# Altium schematic sheet RS232-485.SchDoc(U_RS232-485)
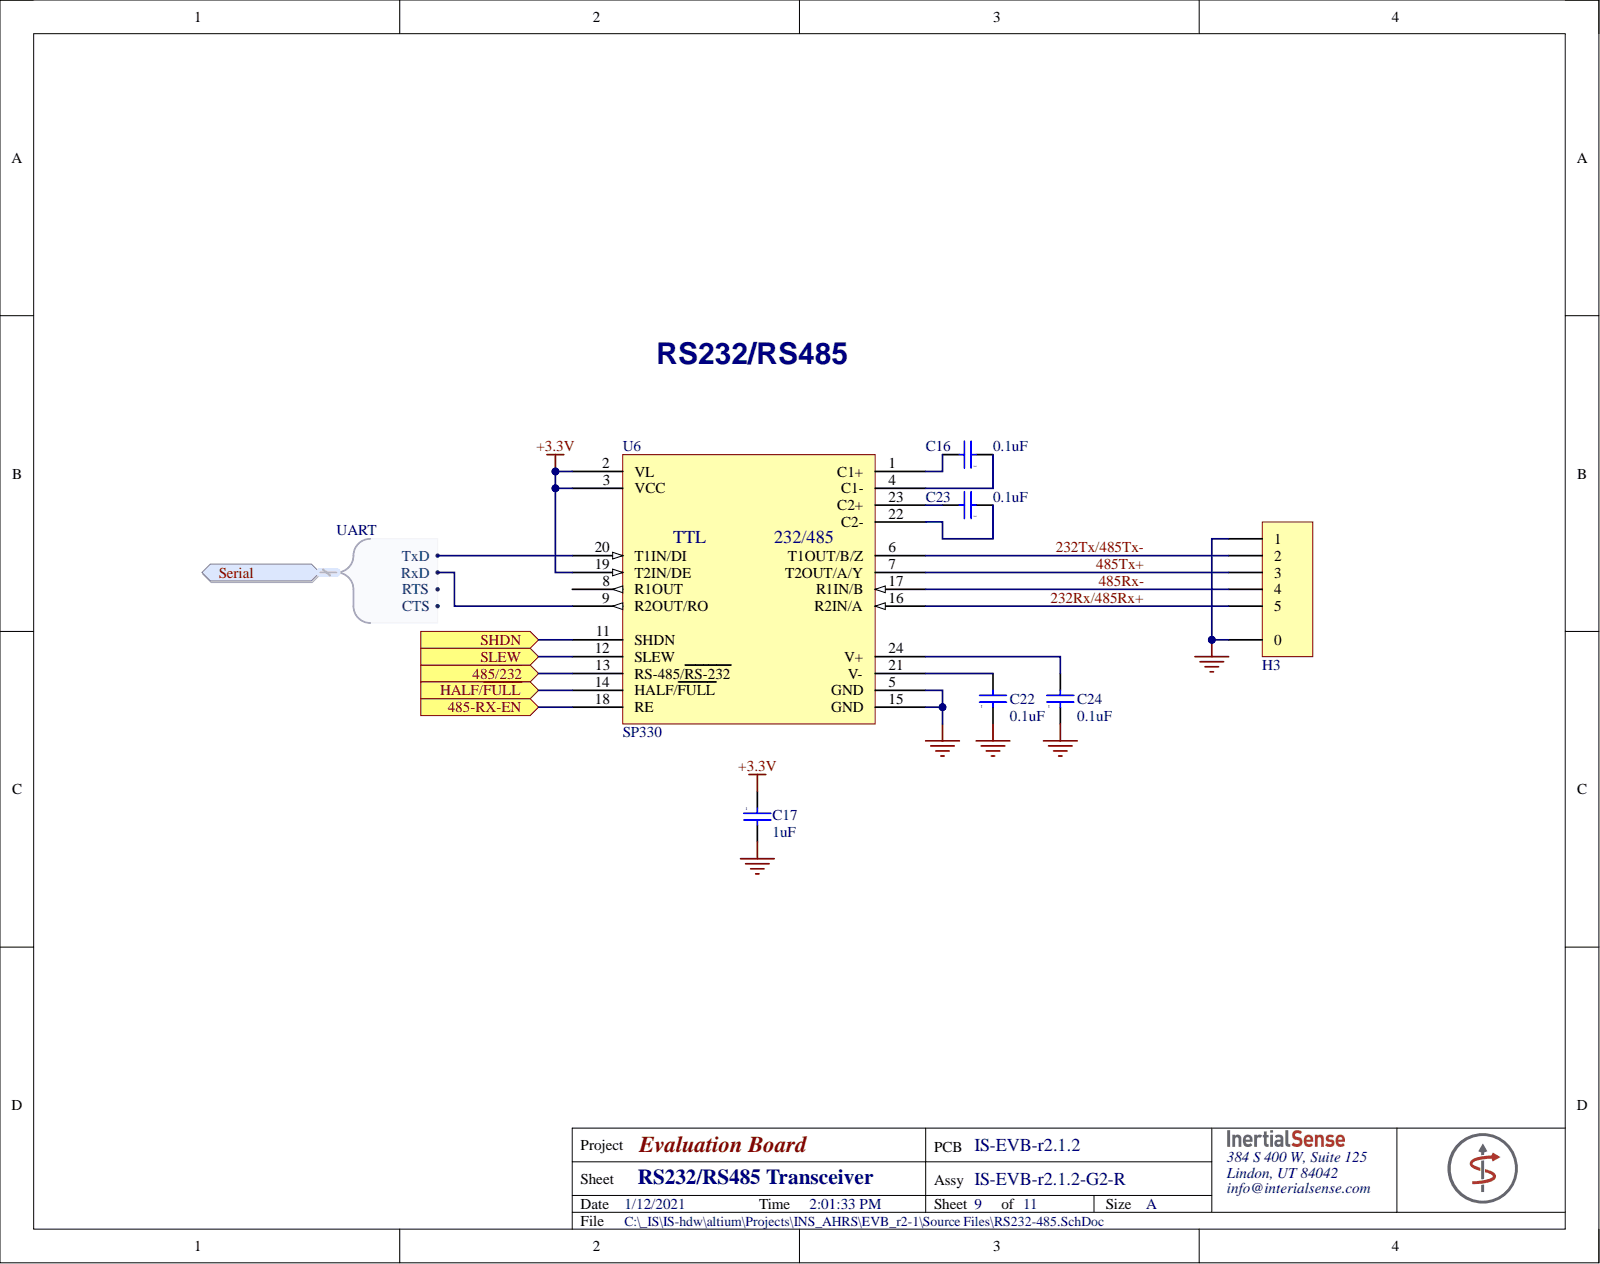
[diagram p0: RS232-485.SchDoc]

## Parts
C16  value 0.1uF  [0402]  (hidden comment: Cap)
C17  value 1uF  Capacitance: 1uF  [0603]  (hidden comment: Cap)
C22  value 0.1uF  [0402]  (hidden comment: Cap)
C23  value 0.1uF  [0402]  (hidden comment: Cap)
C24  value 0.1uF  [0402]  (hidden comment: Cap)
H3  Hdr - 5pin - mount  [SM05B-GHS-TB(LF)(SN)]  (value not drawn)
U6  SP330  [TSOP65P640X120-24N]

## Ports
485-RX-EN, 485/~{232}, HALF/~{FULL}, Serial, Serial.CTS, Serial.RTS, Serial.RxD, Serial.TxD, ~{SHDN}, ~{SLEW}

## Nets
+3.3V: C17.1 U6.2(VL) U6.3(VCC) U6.19(T2IN/DE)
GND: C17.2 C22.1 C24.1 H3.0 H3.1 U6.5(GND) U6.15(GND)
232Rx/485Rx+: H3.5 U6.16(R2IN/A)
232Tx/485Tx-: H3.2 U6.6(T1OUT/B/Z)
485-RX-EN: U6.18(RE) ; port 485-RX-EN
485/~{232}: U6.13(RS-485/RS-232) ; port 485/~{232}
485Rx-: H3.4 U6.17(R1IN/B)
485Tx+: H3.3 U6.7(T2OUT/A/Y)
~{SHDN}: U6.11(SHDN) ; port ~{SHDN}
~{SLEW}: U6.12(SLEW) ; port ~{SLEW}
HALF/~{FULL}: U6.14(HALF/FULL) ; port HALF/~{FULL}
NetC16_1: C16.1 U6.4(C1-)
NetC16_2: C16.2 U6.1(C1+)
NetC22_2: C22.2 U6.21(V-)
NetC23_1: C23.1 U6.22(C2-)
NetC23_2: C23.2 U6.23(C2+)
NetC24_2: C24.2 U6.24(V+)
NetU6_8: U6.8(R1OUT)
NetU6_9: U6.9(R2OUT/RO) ; port Serial
NetU6_20: U6.20(T1IN/DI) ; port Serial
N00023: ; port Serial
N00025: ; port Serial

## Library data of the parts
C16 C22-C24 = Cap: CAP CER .10UF 50V X7R 10% 0402; Manufacturer: TDK Corporation; Manufacturer Part Number: C1005X7R1H104K; Capacitance: 0.1µF; Applications: General Purpose; Category: Capacitors; Component Kind: Standard; ComponentLink1Description: http://www.tdk.co.jp/tefe02/e412_c.pdf; ComponentLink1URL: http://www.tdk.co.jp/tefe02/e412_c.pdf; Features: -; Height: -; LatestRevisionDate: 17-Jul-2002; LatestRevisionNote: Re-released for DXP Platform.; Lead Spacing: -; Lead Style: -; Library Name: General.SchLib; Mounting Type: Surface Mount, MLCC; Operating Temperature: -55°C ~ 125°C; Package / Case: 0402 (1005 Metric); Packaging: Cut Tape (CT); Pricing 1: 1=0.3, 10=0.2, 100=0.08, 250=0.05752, 500=0.041, 1000=0.03 (USD); Published: 8-Jun-2000; Ratings: -; RoHS: RoHS Compliant; Signal Integrity: Cap; Simulation: CAP; Size / Dimension: 0.039" L x 0.020" W (1.00mm x 0.50mm); Stock 1: 174080; Supplier 1: Digi-Key; Supplier Part Number 1: 445-5932-1-ND; Temperature Coefficient: X7R; Thickness: 0.022" (0.55mm); Tolerance: ±10%; Voltage - Rated: 50V; Publisher: Altium Limited
C17 = Cap: CAP CER 1UF 25V X7R 0603; Manufacturer: Samsung; Manufacturer Part Number: CL10B105KA8NNNC; Tolerance: 10%; Case Code (Imperial): 0603; Case Code (Metric): 1608; Case/Package: 0603; Category: Capacitors; Component Kind: Standard; ComponentLink1Description: https://datasheet.ciiva.com/16449/cl10b105ka8nnnc-16449544.pdf; ComponentLink1URL: https://datasheet.ciiva.com/16449/cl10b105ka8nnnc-16449544.pdf; ComponentLink2Description: https://datasheet.ciiva.com/15195/getdatasheetpartid-359554-15195726.pdf; ComponentLink2URL: https://datasheet.ciiva.com/15195/getdatasheetpartid-359554-15195726.pdf; Contact Plating: Tin; Depth: 0.8mm; Dielectric: X7R; Height: 0.8mm; LatestRevisionDate: 17-Jul-2002; LatestRevisionNote: Re-released for DXP Platform.; Length: 1.6mm; Library Name: General.SchLib; Max Operating Temperature: 125°C; Min Operating Temperature: -55°C; Mount: Surface Mount; Package Quantity: 1; Packaging: Tape and Reel; Pricing 1: 1=0.1, 10=0.069, 100=0.0306, 500=0.02188, 1000=0.01719 (USD); Published: 8-Jun-2000; Radiation Hardening: No; Signal Integrity: Cap; Simulation: CAP; Stock 1: 0; Supplier 1: Digi-Key; Supplier Part Number 1: 1276-1184-6-ND; Thickness: 0.035inch; Voltage: 25V; Voltage Rating: 25V; Width: 0.031inch; Publisher: Altium Limited
H3 = Hdr - 5pin - mount: CONN HEADER GH SIDE 5POS 1.25MM; Manufacturer: JST; Connector Type: Outer Shroud Contact; Current Rating: 1A; Number of Contacts: 5; Pitch: 0.049inch; Voltage Rating: 50V; Wire/Cable Gauge: 26AWG; Colour: Natural; Component Kind: Standard; Contact Material: Bronze; Contact Plating: Tin; Fastening Type: Ramp; Housing Colour: Natural; Housing Material: Nylon; Lead Free: Lead Free; Library Name: Connectors.SchLib; Manufacturer Part Number: SM05B-GHS-TB(LF)(SN); Max Operating Temperature: 85°C; Min Operating Temperature: -25°C; Mount: Surface Mount; Number of Positions: 5; Number of Rows: 1; Orientation: Right Angle; Packaging: Tape and Reel; REACH SVHC: No SVHC; Stock 1: 0; Supplier 1: Digi-Key; Supplier Part Number 1: 455-1567-1-ND; Termination: Solder; Voltage Rating (AC): 50V
U6 = SP330: IC TXRX RS232/485 24TSSOP; Manufacturer: Exar; Manufacturer Part Number: SP330EEY-L; Data Rate: 20Mbps; Interface: RS-232,RS-422,RS-485; Max Supply Voltage: 5.5V; Min Supply Voltage: 3V; Number of Drivers: 2; Receiver Hysteresis: 25mV; Simplex/Duplex: Full Duplex; Case/Package: TSSOP; Component Kind: Standard; Height: 1.05mm; Lead Free: Lead Free; Length: 7.9mm; Library Name: ICs.SchLib; Max Operating Temperature: 85°C; Min Operating Temperature: -40°C; Mount: Surface Mount; Number of Pins: 24; Package Quantity: 62; Packaging: Rail/Tube; REACH SVHC: Unknown; Stock 1: 4132; Supplier 1: Digi-Key; Supplier Part Number 1: 1016-1977-ND; Width: 4.5mm
